# Altium schematic sheet PIC32ETH_Pic.SchDoc(U_PIC32ETH_Pic)
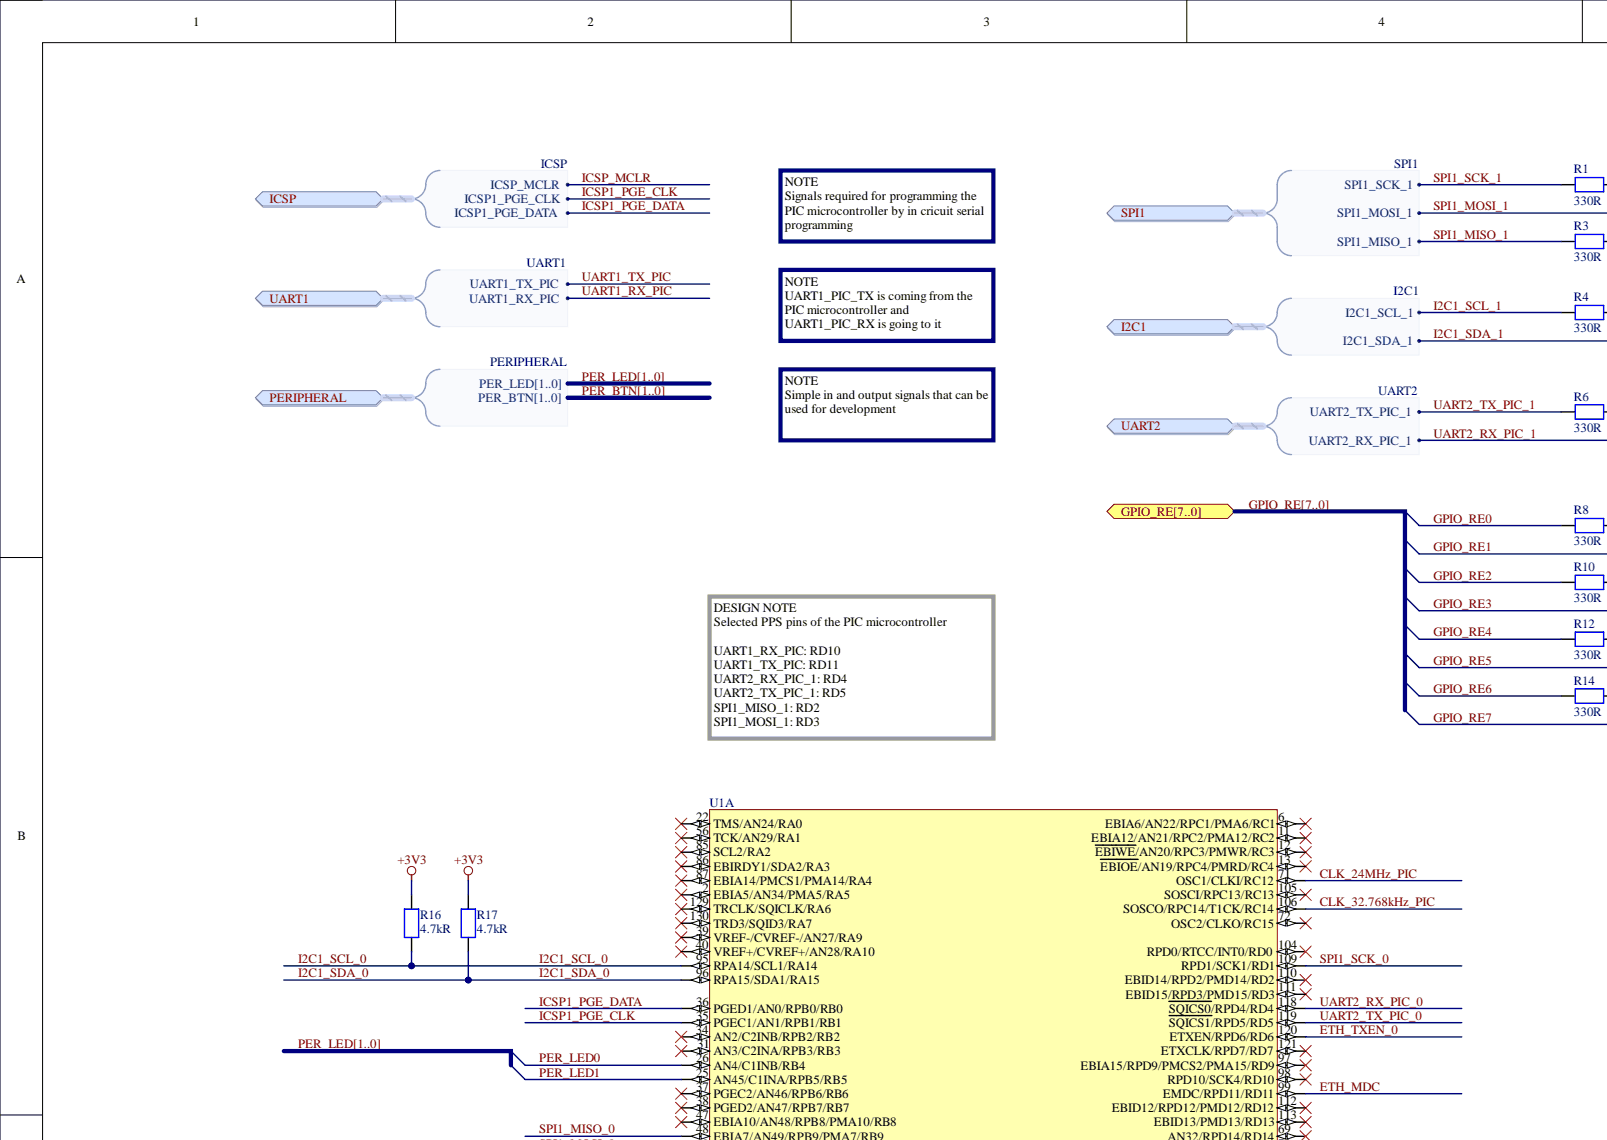
[diagram p0: PIC32ETH_Pic.SchDoc, top left]
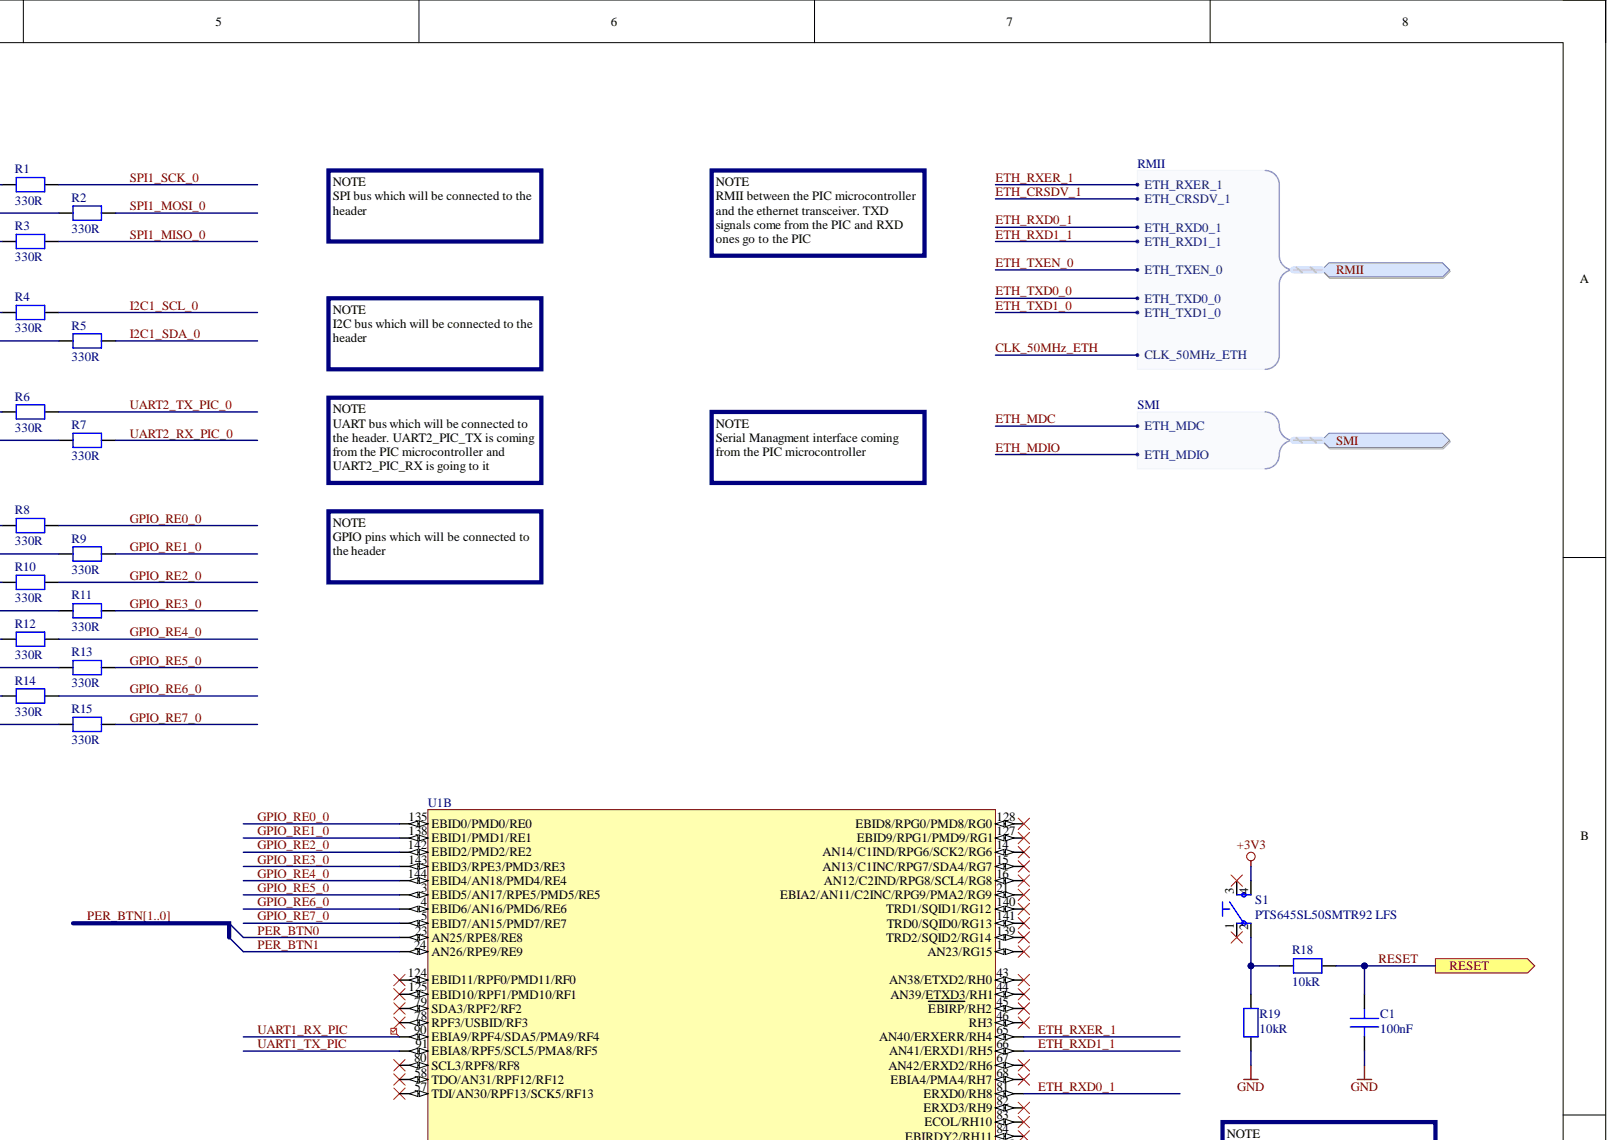
[diagram p1: PIC32ETH_Pic.SchDoc, top right]
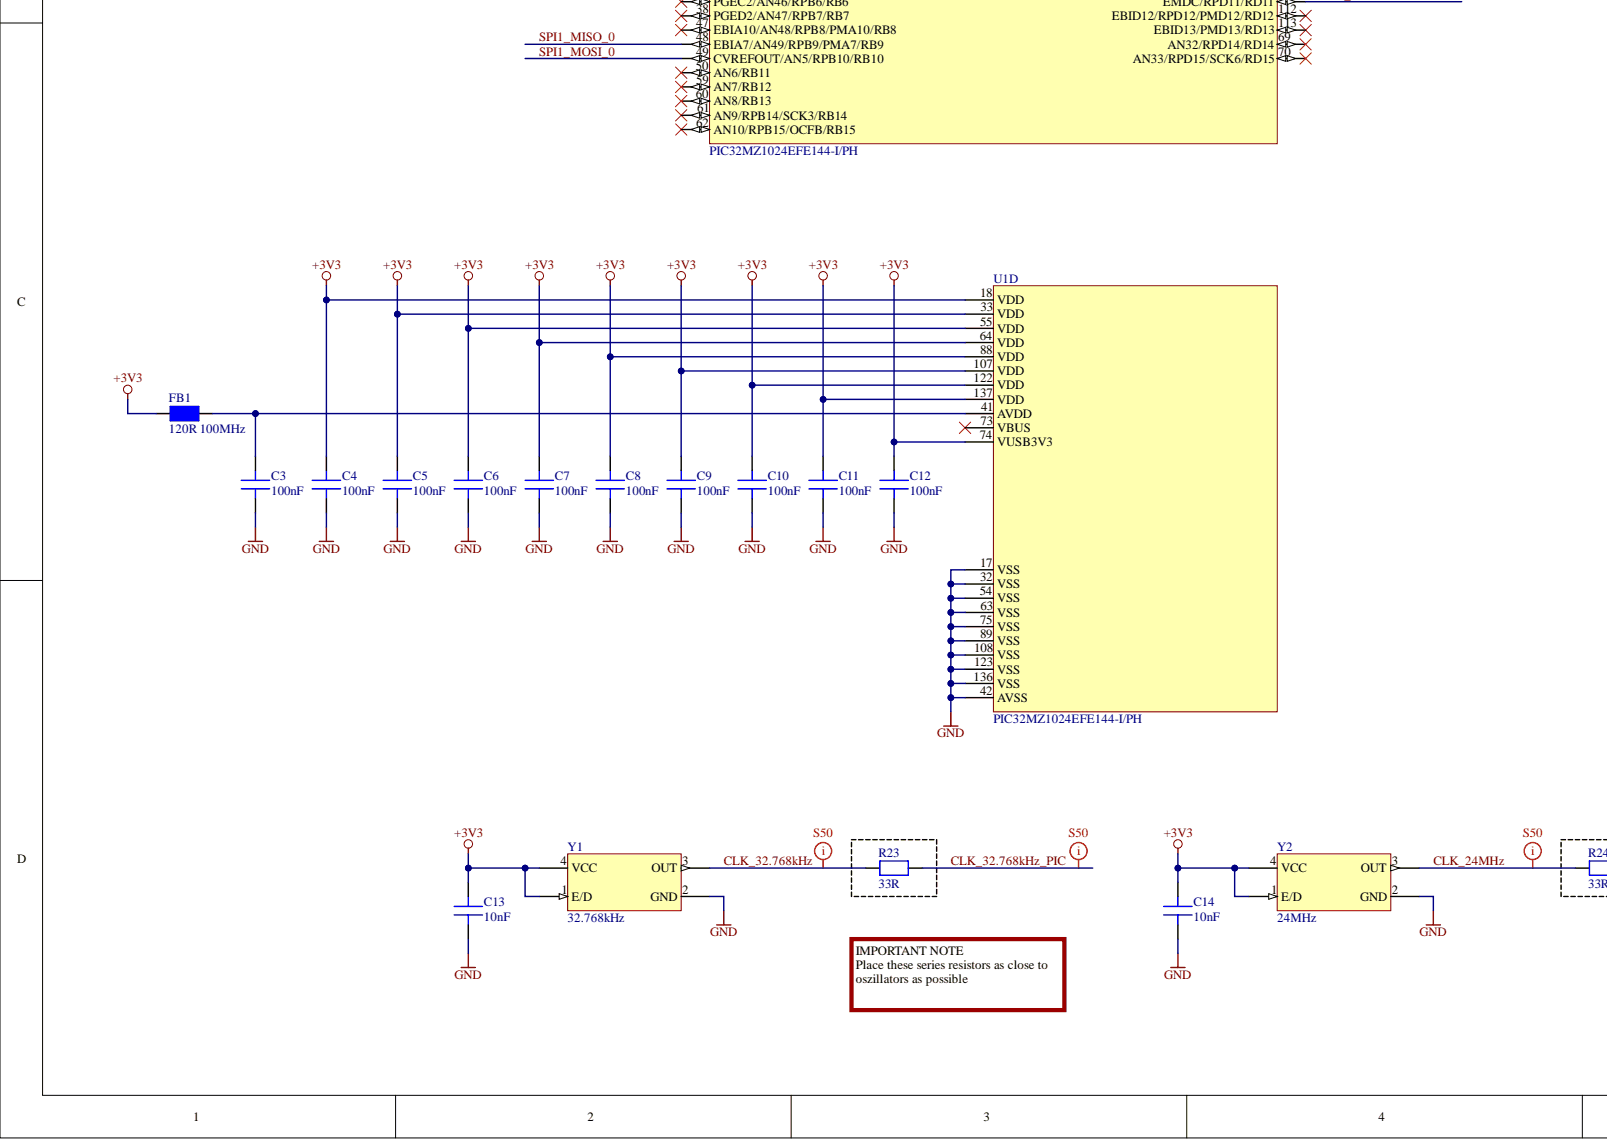
[diagram p2: PIC32ETH_Pic.SchDoc, bottom left]
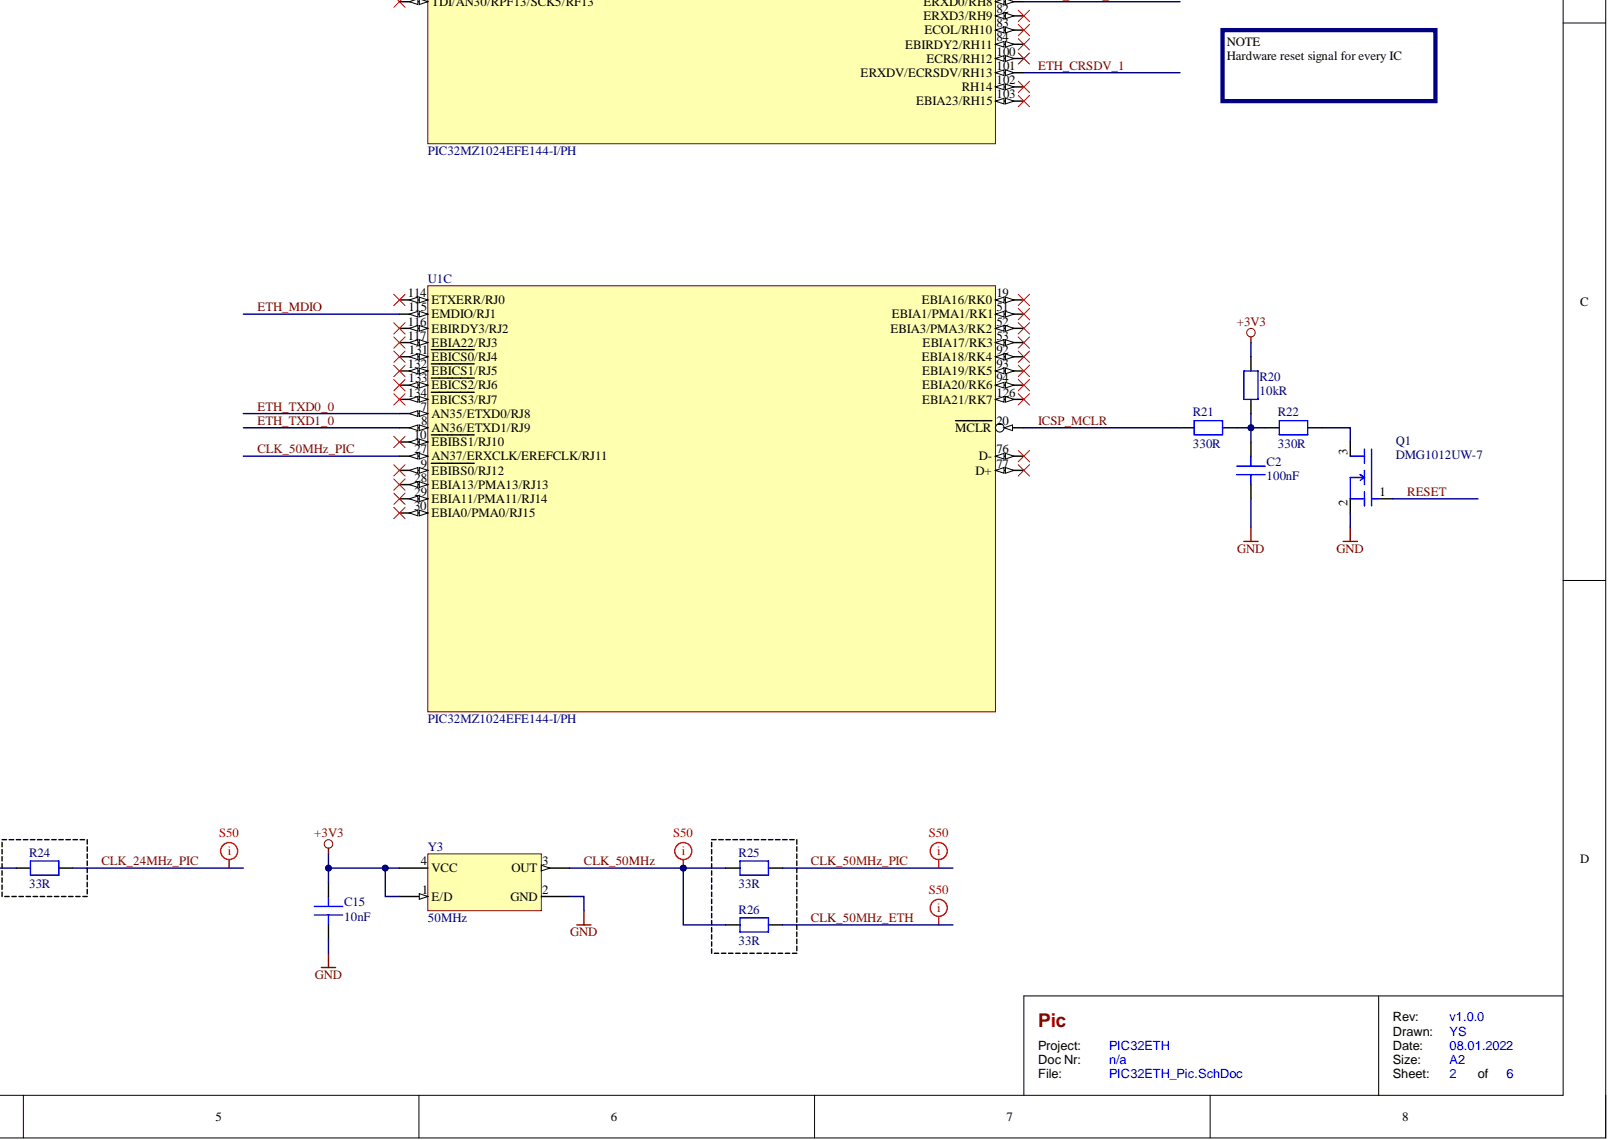
[diagram p3: PIC32ETH_Pic.SchDoc, bottom right]

## Parts
C1  100nF  [C_CHIP2012N]
C2  100nF  [C_CHIP2012N]
C3  100nF  [C_CHIP2012N]
C4  100nF  [C_CHIP2012N]
C5  100nF  [C_CHIP2012N]
C6  100nF  [C_CHIP2012N]
C7  100nF  [C_CHIP2012N]
C8  100nF  [C_CHIP2012N]
C9  100nF  [C_CHIP2012N]
C10  100nF  [C_CHIP2012N]
C11  100nF  [C_CHIP2012N]
C12  100nF  [C_CHIP2012N]
C13  10nF  [C_CHIP2012N]
C14  10nF  [C_CHIP2012N]
C15  10nF  [C_CHIP2012N]
FB1  120R 100MHz  [FB_CHIP3216N]
Q1  DMG1012UW-7  [Q_SOT65P210X100-3N]
R1  330R  [R_CHIP2012N]
R2  330R  [R_CHIP2012N]
R3  330R  [R_CHIP2012N]
R4  330R  [R_CHIP2012N]
R5  330R  [R_CHIP2012N]
R6  330R  [R_CHIP2012N]
R7  330R  [R_CHIP2012N]
R8  330R  [R_CHIP2012N]
R9  330R  [R_CHIP2012N]
R10  330R  [R_CHIP2012N]
R11  330R  [R_CHIP2012N]
R12  330R  [R_CHIP2012N]
R13  330R  [R_CHIP2012N]
R14  330R  [R_CHIP2012N]
R15  330R  [R_CHIP2012N]
R16  4.7kR  [R_CHIP2012N]
R17  4.7kR  [R_CHIP2012N]
R18  10kR  [R_CHIP2012N]
R19  10kR  [R_CHIP2012N]
R20  10kR  [R_CHIP2012N]
R21  330R  [R_CHIP2012N]
R22  330R  [R_CHIP2012N]
R23  33R  [R_CHIP2012N]
R24  33R  [R_CHIP2012N]
R25  33R  [R_CHIP2012N]
R26  33R  [R_CHIP2012N]
S1  PTS645SL50SMTR92 LFS  [S_CHIPP620X620-4N]
U1  PIC32MZ1024EFE144-I/PH  [U_QFP40P1800X1800X120-144N]  (value not drawn)  (parts A,B,C,D)
Y1  32.768kHz  [Y_CHIPP320X250-4N]
Y2  24MHz  [Y_CHIPP320X250-4N]
Y3  50MHz  [Y_CHIPP320X250-4N]

## Ports
GPIO_RE0, GPIO_RE1, GPIO_RE2, GPIO_RE3, GPIO_RE4, GPIO_RE5, GPIO_RE6, GPIO_RE7, GPIO_RE[7..0], I2C1, I2C1.I2C1_SCL_1, I2C1.I2C1_SDA_1, ICSP, ICSP.ICSP1_PGE_CLK, ICSP.ICSP1_PGE_DATA, ICSP.ICSP_MCLR, PERIPHERAL, PERIPHERAL.PER_BTN0, PERIPHERAL.PER_BTN1, PERIPHERAL.PER_BTN[1..0], PERIPHERAL.PER_LED0, PERIPHERAL.PER_LED1, PERIPHERAL.PER_LED[1..0], RESET, RMII, RMII.CLK_50MHz_ETH, RMII.ETH_CRSDV_1, RMII.ETH_RXD0_1, RMII.ETH_RXD1_1, RMII.ETH_RXER_1, RMII.ETH_TXD0_0, RMII.ETH_TXD1_0, RMII.ETH_TXEN_0, SMI, SMI.ETH_MDC, SMI.ETH_MDIO, SPI1, SPI1.SPI1_MISO_1, SPI1.SPI1_MOSI_1, SPI1.SPI1_SCK_1, UART1, UART1.UART1_RX_PIC, UART1.UART1_TX_PIC, UART2, UART2.UART2_RX_PIC_1, UART2.UART2_TX_PIC_1

## Nets
+3V3: C4.1 C5.1 C6.1 C7.1 C8.1 C9.1 C10.1 C11.1 C12.1 C13.2 C14.2 C15.2 FB1.1 R16.2 R17.2 R20.2 S1.4 U1.18(VDD) U1.33(VDD) U1.55(VDD) U1.64(VDD) U1.74(VUSB3V3) U1.88(VDD) U1.107(VDD) U1.122(VDD) U1.137(VDD) Y1.1(E/D) Y1.4(VCC) Y2.1(E/D) Y2.4(VCC) Y3.1(E/D) Y3.4(VCC)
GND: C1.2 C2.2 C3.2 C4.2 C5.2 C6.2 C7.2 C8.2 C9.2 C10.2 C11.2 C12.2 C13.1 C14.1 C15.1 Q1.2 R19.1 U1.17(VSS) U1.32(VSS) U1.42(AVSS) U1.54(VSS) U1.63(VSS) U1.75(VSS) U1.89(VSS) U1.108(VSS) U1.123(VSS) U1.136(VSS) Y1.2(GND) Y2.2(GND) Y3.2(GND)
CLK_24MHz: R24.1 Y2.3(OUT)
CLK_24MHz_PIC: R24.2 U1.71(OSC1/CLKI/RC12)
CLK_32.768kHz: R23.1 Y1.3(OUT)
CLK_32.768kHz_PIC: R23.2 U1.106(SOSCO/RPC14/T1CK/RC14)
CLK_50MHz: R25.1 R26.1 Y3.3(OUT)
CLK_50MHz_ETH: R26.2 ; port RMII
CLK_50MHz_PIC: R25.2 U1.27(AN37/ERXCLK/EREFCLK/RJ11)
ETH_CRSDV_1: U1.101(ERXDV/ECRSDV/RH13) ; port RMII
ETH_MDC: U1.99(EMDC/RPD11/RD11) ; port SMI
ETH_MDIO: U1.115(EMDIO/RJ1) ; port SMI
ETH_RXD0_1: U1.81(ERXD0/RH8) ; port RMII
ETH_RXD1_1: U1.66(AN41/ERXD1/RH5) ; port RMII
ETH_RXER_1: U1.65(AN40/ERXERR/RH4) ; port RMII
ETH_TXD0_0: U1.7(AN35/ETXD0/RJ8) ; port RMII
ETH_TXD1_0: U1.8(AN36/ETXD1/RJ9) ; port RMII
ETH_TXEN_0: U1.120(ETXEN/RPD6/RD6) ; port RMII
GPIO_RE0: R8.1 ; port GPIO_RE[7..0]  [also labelled GPIO_RE]
GPIO_RE0_0: R8.2 U1.135(EBID0/PMD0/RE0)
GPIO_RE1: R9.1 ; port GPIO_RE[7..0]  [also labelled GPIO_RE]
GPIO_RE1_0: R9.2 U1.138(EBID1/PMD1/RE1)
GPIO_RE2: R10.1 ; port GPIO_RE[7..0]  [also labelled GPIO_RE]
GPIO_RE2_0: R10.2 U1.142(EBID2/PMD2/RE2)
GPIO_RE3: R11.1 ; port GPIO_RE[7..0]  [also labelled GPIO_RE]
GPIO_RE3_0: R11.2 U1.143(EBID3/RPE3/PMD3/RE3)
GPIO_RE4: R12.1 ; port GPIO_RE[7..0]  [also labelled GPIO_RE]
GPIO_RE4_0: R12.2 U1.144(EBID4/AN18/PMD4/RE4)
GPIO_RE5: R13.1 ; port GPIO_RE[7..0]  [also labelled GPIO_RE]
GPIO_RE5_0: R13.2 U1.3(EBID5/AN17/RPE5/PMD5/RE5)
GPIO_RE6: R14.1 ; port GPIO_RE[7..0]  [also labelled GPIO_RE]
GPIO_RE6_0: R14.2 U1.4(EBID6/AN16/PMD6/RE6)
GPIO_RE7: R15.1 ; port GPIO_RE[7..0]  [also labelled GPIO_RE]
GPIO_RE7_0: R15.2 U1.5(EBID7/AN15/PMD7/RE7)
I2C1_SCL_0: R4.2 R16.1 U1.95(RPA14/SCL1/RA14)
I2C1_SCL_1: R4.1 ; port I2C1
I2C1_SDA_0: R5.2 R17.1 U1.96(RPA15/SDA1/RA15)
I2C1_SDA_1: R5.1 ; port I2C1
ICSP1_PGE_CLK: U1.35(PGEC1/AN1/RPB1/RB1) ; port ICSP
ICSP1_PGE_DATA: U1.36(PGED1/AN0/RPB0/RB0) ; port ICSP
ICSP_MCLR: R21.1 U1.20(MCLR) ; port ICSP
PER_BTN0: U1.23(AN25/RPE8/RE8) ; port PERIPHERAL  [also labelled PER_BTN]
PER_BTN1: U1.24(AN26/RPE9/RE9) ; port PERIPHERAL  [also labelled PER_BTN]
PER_LED0: U1.26(AN4/C1INB/RB4) ; port PERIPHERAL  [also labelled PER_LED]
PER_LED1: U1.25(AN45/C1INA/RPB5/RB5) ; port PERIPHERAL  [also labelled PER_LED]
RESET: C1.1 Q1.1 R18.1 ; port RESET
SPI1_MISO_0: R3.2 U1.48(EBIA7/AN49/RPB9/PMA7/RB9)
SPI1_MISO_1: R3.1 ; port SPI1
SPI1_MOSI_0: R2.2 U1.49(CVREFOUT/AN5/RPB10/RB10)
SPI1_MOSI_1: R2.1 ; port SPI1
SPI1_SCK_0: R1.2 U1.109(RPD1/SCK1/RD1)
SPI1_SCK_1: R1.1 ; port SPI1
UART1_RX_PIC: U1.90(EBIA9/RPF4/SDA5/PMA9/RF4) ; port UART1
UART1_TX_PIC: U1.91(EBIA8/RPF5/SCL5/PMA8/RF5) ; port UART1
UART2_RX_PIC_0: R7.2 U1.118(SQICS0/RPD4/RD4)
UART2_RX_PIC_1: R7.1 ; port UART2
UART2_TX_PIC_0: R6.2 U1.119(SQICS1/RPD5/RD5)
UART2_TX_PIC_1: R6.1 ; port UART2
NetC2_1: C2.1 R20.1 R21.2 R22.1
NetC3_1: C3.1 FB1.2 U1.41(AVDD)
NetQ1_3: Q1.3 R22.2
NetR18_2: R18.2 R19.2 S1.2
NetS1_1: S1.1
NetS1_3: S1.3
NetU1_1: U1.1(AN23/RG15)
NetU1_2: U1.2(EBIA5/AN34/PMA5/RA5)
NetU1_6: U1.6(EBIA6/AN22/RPC1/PMA6/RC1)
NetU1_9: U1.9(EBIBS0/RJ12)
NetU1_10: U1.10(EBIBS1/RJ10)
NetU1_11: U1.11(EBIA12/AN21/RPC2/PMA12/RC2)
NetU1_12: U1.12(EBIWE/AN20/RPC3/PMWR/RC3)
NetU1_13: U1.13(EBIOE/AN19/RPC4/PMRD/RC4)
NetU1_14: U1.14(AN14/C1IND/RPG6/SCK2/RG6)
NetU1_15: U1.15(AN13/C1INC/RPG7/SDA4/RG7)
NetU1_16: U1.16(AN12/C2IND/RPG8/SCL4/RG8)
NetU1_19: U1.19(EBIA16/RK0)
NetU1_21: U1.21(EBIA2/AN11/C2INC/RPG9/PMA2/RG9)
NetU1_22: U1.22(TMS/AN24/RA0)
NetU1_28: U1.28(EBIA13/PMA13/RJ13)
NetU1_29: U1.29(EBIA11/PMA11/RJ14)
NetU1_30: U1.30(EBIA0/PMA0/RJ15)
NetU1_31: U1.31(AN3/C2INA/RPB3/RB3)
NetU1_34: U1.34(AN2/C2INB/RPB2/RB2)
NetU1_37: U1.37(PGEC2/AN46/RPB6/RB6)
NetU1_38: U1.38(PGED2/AN47/RPB7/RB7)
NetU1_39: U1.39(VREF-/CVREF-/AN27/RA9)
NetU1_40: U1.40(VREF+/CVREF+/AN28/RA10)
NetU1_43: U1.43(AN38/ETXD2/RH0)
NetU1_44: U1.44(AN39/ETXD3/RH1)
NetU1_45: U1.45(EBIRP/RH2)
NetU1_46: U1.46(RH3)
NetU1_47: U1.47(EBIA10/AN48/RPB8/PMA10/RB8)
NetU1_50: U1.50(AN6/RB11)
NetU1_51: U1.51(EBIA1/PMA1/RK1)
NetU1_52: U1.52(EBIA3/PMA3/RK2)
NetU1_53: U1.53(EBIA17/RK3)
NetU1_56: U1.56(TCK/AN29/RA1)
NetU1_57: U1.57(TDI/AN30/RPF13/SCK5/RF13)
NetU1_58: U1.58(TDO/AN31/RPF12/RF12)
NetU1_59: U1.59(AN7/RB12)
NetU1_60: U1.60(AN8/RB13)
NetU1_61: U1.61(AN9/RPB14/SCK3/RB14)
NetU1_62: U1.62(AN10/RPB15/OCFB/RB15)
NetU1_67: U1.67(AN42/ERXD2/RH6)
NetU1_68: U1.68(EBIA4/PMA4/RH7)
NetU1_69: U1.69(AN32/RPD14/RD14)
NetU1_70: U1.70(AN33/RPD15/SCK6/RD15)
NetU1_72: U1.72(OSC2/CLKO/RC15)
NetU1_73: U1.73(VBUS)
NetU1_76: U1.76(D-)
NetU1_77: U1.77(D+)
NetU1_78: U1.78(RPF3/USBID/RF3)
NetU1_79: U1.79(SDA3/RPF2/RF2)
NetU1_80: U1.80(SCL3/RPF8/RF8)
NetU1_82: U1.82(ERXD3/RH9)
NetU1_83: U1.83(ECOL/RH10)
NetU1_84: U1.84(EBIRDY2/RH11)
NetU1_85: U1.85(SCL2/RA2)
NetU1_86: U1.86(EBIRDY1/SDA2/RA3)
NetU1_87: U1.87(EBIA14/PMCS1/PMA14/RA4)
NetU1_92: U1.92(EBIA18/RK4)
NetU1_93: U1.93(EBIA19/RK5)
NetU1_94: U1.94(EBIA20/RK6)
NetU1_97: U1.97(EBIA15/RPD9/PMCS2/PMA15/RD9)
NetU1_98: U1.98(RPD10/SCK4/RD10)
NetU1_100: U1.100(ECRS/RH12)
NetU1_102: U1.102(RH14)
NetU1_103: U1.103(EBIA23/RH15)
NetU1_104: U1.104(RPD0/RTCC/INT0/RD0)
NetU1_105: U1.105(SOSCI/RPC13/RC13)
NetU1_110: U1.110(EBID14/RPD2/PMD14/RD2)
NetU1_111: U1.111(EBID15/RPD3/PMD15/RD3)
NetU1_112: U1.112(EBID12/RPD12/PMD12/RD12)
NetU1_113: U1.113(EBID13/PMD13/RD13)
NetU1_114: U1.114(ETXERR/RJ0)
NetU1_116: U1.116(EBIRDY3/RJ2)
NetU1_117: U1.117(EBIA22/RJ3)
NetU1_121: U1.121(ETXCLK/RPD7/RD7)
NetU1_124: U1.124(EBID11/RPF0/PMD11/RF0)
NetU1_125: U1.125(EBID10/RPF1/PMD10/RF1)
NetU1_126: U1.126(EBIA21/RK7)
NetU1_127: U1.127(EBID9/RPG1/PMD9/RG1)
NetU1_128: U1.128(EBID8/RPG0/PMD8/RG0)
NetU1_129: U1.129(TRCLK/SQICLK/RA6)
NetU1_130: U1.130(TRD3/SQID3/RA7)
NetU1_131: U1.131(EBICS0/RJ4)
NetU1_132: U1.132(EBICS1/RJ5)
NetU1_133: U1.133(EBICS2/RJ6)
NetU1_134: U1.134(EBICS3/RJ7)
NetU1_139: U1.139(TRD2/SQID2/RG14)
NetU1_140: U1.140(TRD1/SQID1/RG12)
NetU1_141: U1.141(TRD0/SQID0/RG13)

## Library data of the parts
C1-C12 = C_C0805C104K5RACTU: C CERAMIC 100nF 50V 10% 2012; Manufacturer: Kemet; Dielectric: X7R; Tolerance: +/-10%; Capacitance: 100nF; Component Kind: Standard; Datasheet Url: https://content.kemet.com/datasheets/KEM_C1002_X7R_SMD.pdf; Esr: n/a; Library Name: PIC32ETH.SchLib; Mounting: SMT; Package: 2012; Part Number: C0805C104K5RACTU; Pin Count: 2; Price: 0.23; Price Currency: CHF; Supplier 1: Digi-Key; Supplier Part Number 1: 399-1170-1-ND; Temperature Range: -55degC to 125degC; Voltage: 50V
C13-C15 = C_C0805C103K5RACTU: C CERAMIC 10nF 50V 10% 2012; Manufacturer: Kemet; Dielectric: X7R; Tolerance: +/-10%; Capacitance: 10nF; Component Kind: Standard; Datasheet Url: https://content.kemet.com/datasheets/KEM_C1002_X7R_SMD.pdf; Esr: n/a; Library Name: PIC32ETH.SchLib; Mounting: SMT; Package: 2012; Part Number: C0805C103K5RACTU; Pin Count: 2; Price: 0.18; Price Currency: CHF; Supplier 1: Digi-Key; Supplier Part Number 1: 399-1158-1-ND; Temperature Range: -55degC to 125degC; Voltage: 50V
FB1 = FB_BLM31KN121SN1L: FB 120R 100MHz 6A 3216; Manufacturer: Murata Electronics; Resistance: 9mR; Component Kind: Standard; Current: 6A; Datasheet Url: https://www.murata.com/en-us/products/productdata/8801529331742/ENFA0091.pdf; Impedance: 120R 100MHz; Library Name: PIC32ETH.SchLib; Mounting: SMT; Package: 3216; Part Number: BLM31KN121SN1L; Pin Count: 2; Price: 0.29; Price Currency: CHF; Supplier 1: Digi-Key; Supplier Part Number 1: 490-16524-1-ND; Temperature Range: -55degC to 125degC
Q1 = Q_DMG1012UW-7: Q MOSFET N-CH 20V 1A SOT-323; Manufacturer: Diodes Incorporated; Power: 290mW; Voltage Gate Source: +/-6V; Component Kind: Standard; Current Drain: 1A; Datasheet Url: https://www.diodes.com/assets/Datasheets/ds31859.pdf; Library Name: PIC32ETH.SchLib; Mosfet Type: N-CH; Mounting: SMT; Package: SOT-323; Part Number: DMG1012UW-7; Pin Count: 3; Price: 0.39; Price Currency: CHF; Supplier 1: Digi-Key; Supplier Part Number 1: DMG1012UW-7DICT-ND; Temperature Range: -55degC to 150degC; Voltage Drain Source: 20V
R1-R15 R21 R22 = R_RMCF0805FT330R: R SMT 330R 1% 125mW 2012; Manufacturer: Stackpole Electronics Inc; Temperature Coefficient: 100ppm/degC; Tolerance: +/-1%; Voltage: 150V; Component Kind: Standard; Datasheet Url: https://www.seielect.com/catalog/sei-rmcf_rmcp.pdf; Library Name: PIC32ETH.SchLib; Mounting: SMT; Package: 2012; Part Number: RMCF0805FT330R; Pin Count: 2; Power: 125mW; Price: 0.10; Price Currency: CHF; Resistance: 330R; Supplier 1: Digi-Key; Supplier Part Number 1: RMCF0805FT330RCT-ND; Temperature Range: -55degC to 155degC
R16 R17 = R_RMCF0805FT4K70: R SMT 4.7kR 1% 125mW 2012; Manufacturer: Stackpole Electronics Inc; Temperature Coefficient: 100ppm/degC; Tolerance: +/-1%; Voltage: 150V; Component Kind: Standard; Datasheet Url: https://www.seielect.com/catalog/sei-rmcf_rmcp.pdf; Library Name: PIC32ETH.SchLib; Mounting: SMT; Package: 2012; Part Number: RMCF0805FT4K70; Pin Count: 2; Power: 125mW; Price: 0.10; Price Currency: CHF; Resistance: 4.7kR; Supplier 1: Digi-Key; Supplier Part Number 1: RMCF0805FT4K70CT-ND; Temperature Range: -55degC to 155degC
R18-R20 = R_RMCF0805FT10K0: R SMT 10kR 1% 125mW 2012; Manufacturer: Stackpole Electronics Inc; Temperature Coefficient: 100ppm/degC; Tolerance: +/-1%; Voltage: 150V; Component Kind: Standard; Datasheet Url: https://www.seielect.com/catalog/sei-rmcf_rmcp.pdf; Library Name: PIC32ETH.SchLib; Mounting: SMT; Package: 2012; Part Number: RMCF0805FT10K0; Pin Count: 2; Power: 125mW; Price: 0.10; Price Currency: CHF; Resistance: 10kR; Supplier 1: Digi-Key; Supplier Part Number 1: RMCF0805FT10K0CT-ND; Temperature Range: -55degC to 155degC
R23-R26 = R_RMCF0805FT33R0: R SMT 33R 1% 125mW 2012; Manufacturer: Stackpole Electronics Inc; Temperature Coefficient: 100ppm/degC; Tolerance: +/-1%; Voltage: 150V; Component Kind: Standard; Datasheet Url: https://www.seielect.com/catalog/sei-rmcf_rmcp.pdf; Library Name: PIC32ETH.SchLib; Mounting: SMT; Package: 2012; Part Number: RMCF0805FT33R0; Pin Count: 2; Power: 125mW; Price: 0.10; Price Currency: CHF; Resistance: 33R; Supplier 1: Digi-Key; Supplier Part Number 1: RMCF0805FT33R0CT-ND; Temperature Range: -55degC to 155degC
S1 = S_PTS645SL50SMTR92LFS: S TACTILE SPST-NO 12V 50mA SMT; Manufacturer: C&K; Cycles Mechanical: 100000; Component Kind: Standard; Current: 50mA; Cycles Electrical: n/a; Datasheet Url: https://dznh3ojzb2azq.cloudfront.net/products/Tactile/PTS645/documents/datasheet.pdf; Library Name: PIC32ETH.SchLib; Mounting: SMT; Package: n/a; Part Number: PTS645SL50SMTR92 LFS; Pin Count: 4; Price: 0.30; Price Currency: CHF; Supplier 1: Digi-Key; Supplier Part Number 1: CKN9088CT-ND; Switch Type: SPST-NO; Temperature Range: -20degC to 60degC; Voltage: 12V
U1 = U_PIC32MZ1024EFE144-I/PH: U MCU 32BIT 1MB 144-TQFP; Manufacturer: Microchip Technology; Bit Count: 32; Current Output: 5mA; Voltage Input: 2.1V to 3.6V; Component Kind: Standard; Datasheet Url: https://www.mouser.ch/datasheet/2/268/mchp_s_a0007771640_1-2274641.pdf; Library Name: PIC32ETH.SchLib; Memory Size: 1MB; Mounting: SMT; Package: 144-TQFP; Part Number: PIC32MZ1024EFE144-I/PH; Pin Count: 144; Price: 13.12; Price Currency: CHF; Supplier 1: Mouser; Supplier Part Number 1: 579-MZ1024EFE144IPH; Temperature Range: -40degC to 85degC
Y1 = Y_ECS-327ATQMV-AS-TR: Y OSCILLATOR 32.768kHz CMOS SMT; Manufacturer: ECS Inc.; Current: 200uA; Voltage: 1.62V to 3.63V; Component Kind: Standard; Datasheet Url: https://ecsxtal.com/store/pdf/ECS-327ATQMV.pdf; Frequency: 32.768kHz; Library Name: PIC32ETH.SchLib; Mounting: SMT; Package: n/a; Part Number: ECS-327ATQMV-AS-TR; Pin Count: 4; Price: 1.27; Price Currency: CHF; Supplier 1: Digi-Key; Supplier Part Number 1: XC2826CT-ND; Technology: CMOS; Temperature Range: -40degC to 125degC
Y2 = Y_ECS-3225MV-240-CN-TR: Y OSCILLATOR 24MHz HCMOS SMT; Manufacturer: ECS Inc.; Current: 4mA; Voltage: 1.62V to 3.63V; Component Kind: Standard; Datasheet Url: https://ecsxtal.com/store/pdf/ECS-3225MV.pdf; Frequency: 24MHz; Library Name: PIC32ETH.SchLib; Mounting: SMT; Package: n/a; Part Number: ECS-3225MV-240-CN-TR; Pin Count: 4; Price: 1.20; Price Currency: CHF; Supplier 1: Digi-Key; Supplier Part Number 1: XC2884CT-ND; Technology: HCMOS; Temperature Range: -40degC to 85degC
Y3 = Y_ECS-3225MV-500-BN-TR: Y OSCILLATOR 50MHz HCMOS SMT; Manufacturer: ECS Inc.; Current: 5mA; Voltage: 1.62V to 3.63V; Component Kind: Standard; Datasheet Url: https://ecsxtal.com/store/pdf/ECS-3225MV.pdf; Frequency: 50MHz; Library Name: PIC32ETH.SchLib; Mounting: SMT; Package: n/a; Part Number: ECS-3225MV-500-BN-TR; Pin Count: 4; Price: 1.21; Price Currency: CHF; Supplier 1: Digi-Key; Supplier Part Number 1: XC2881CT-ND; Technology: HCMOS; Temperature Range: -40degC to 85degC
